# Altium schematic sheet DTRIG.SchDoc
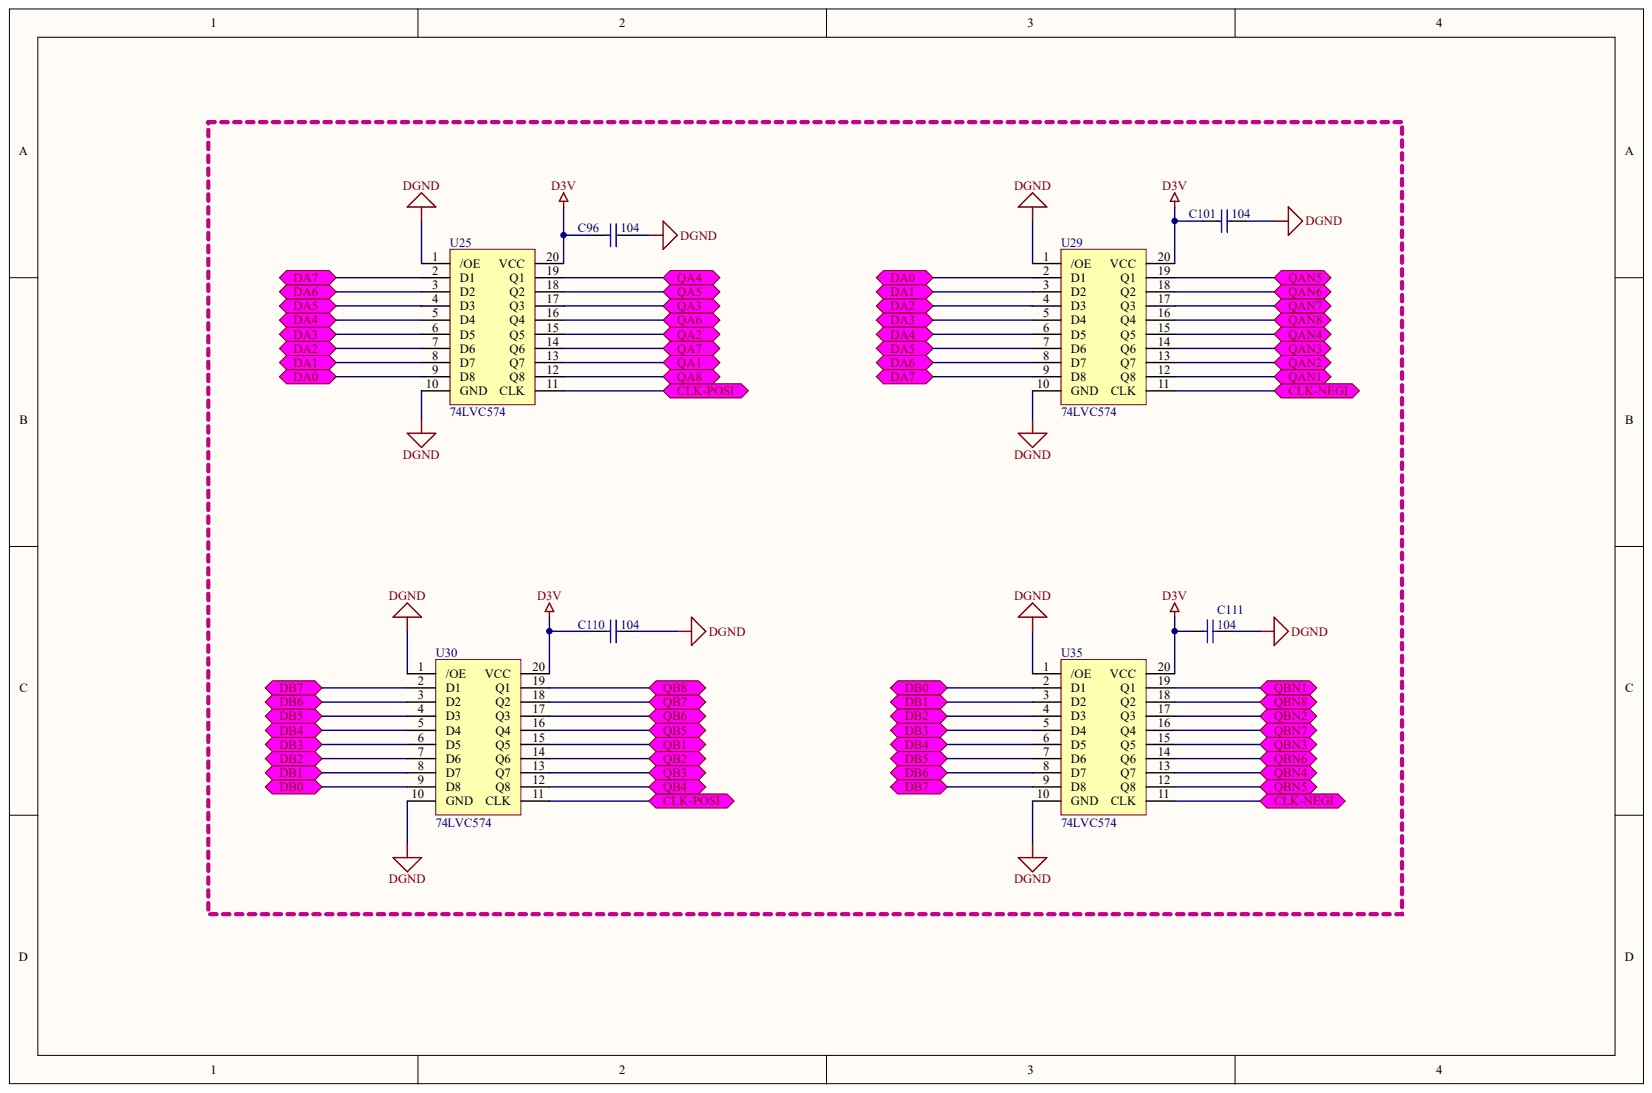
[diagram p0: DTRIG.SchDoc]

## Parts
C96  C104  (value not drawn)
C101  C104  (value not drawn)
C110  C104  (value not drawn)
C111  C104  (value not drawn)
U25  74LVC574
U29  74LVC574
U30  74LVC574
U35  74LVC574

## Ports
CLK-NEGI, CLK-POSI, DA0, DA1, DA2, DA3, DA4, DA5, DA6, DA7, DB0, DB1, DB2, DB3, DB4, DB5, DB6, DB7, QA1, QA2, QA3, QA4, QA5, QA6, QA7, QA8, QAN1, QAN2, QAN3, QAN4, QAN5, QAN6, QAN7, QAN8, QB1, QB2, QB3, QB4, QB5, QB6, QB7, QB8, QBN1, QBN2, QBN3, QBN4, QBN5, QBN6, QBN7, QBN8

## Nets
D3V: C96.1 C101.1 C110.1 C111.1 U25.20(VCC) U29.20(VCC) U30.20(VCC) U35.20(VCC)
DGND: C96.2 C101.2 C110.2 C111.2 U25.1(/OE) U25.10(GND) U29.1(/OE) U29.10(GND) U30.1(/OE) U30.10(GND) U35.1(/OE) U35.10(GND)
CLK-NEGI: U29.11(CLK) U35.11(CLK) ; port CLK-NEGI
CLK-POSI: U25.11(CLK) U30.11(CLK) ; port CLK-POSI
DA0: U25.9(D8) U29.2(D1) ; port DA0
DA1: U25.8(D7) U29.3(D2) ; port DA1
DA2: U25.7(D6) U29.4(D3) ; port DA2
DA3: U25.6(D5) U29.5(D4) ; port DA3
DA4: U25.5(D4) U29.6(D5) ; port DA4
DA5: U25.4(D3) U29.7(D6) ; port DA5
DA6: U25.3(D2) U29.8(D7) ; port DA6
DA7: U25.2(D1) U29.9(D8) ; port DA7
DB0: U30.9(D8) U35.2(D1) ; port DB0
DB1: U30.8(D7) U35.3(D2) ; port DB1
DB2: U30.7(D6) U35.4(D3) ; port DB2
DB3: U30.6(D5) U35.5(D4) ; port DB3
DB4: U30.5(D4) U35.6(D5) ; port DB4
DB5: U30.4(D3) U35.7(D6) ; port DB5
DB6: U30.3(D2) U35.8(D7) ; port DB6
DB7: U30.2(D1) U35.9(D8) ; port DB7
QA1: U25.13(Q7) ; port QA1
QA2: U25.15(Q5) ; port QA2
QA3: U25.17(Q3) ; port QA3
QA4: U25.19(Q1) ; port QA4
QA5: U25.18(Q2) ; port QA5
QA6: U25.16(Q4) ; port QA6
QA7: U25.14(Q6) ; port QA7
QA8: U25.12(Q8) ; port QA8
QAN1: U29.12(Q8) ; port QAN1
QAN2: U29.13(Q7) ; port QAN2
QAN3: U29.14(Q6) ; port QAN3
QAN4: U29.15(Q5) ; port QAN4
QAN5: U29.19(Q1) ; port QAN5
QAN6: U29.18(Q2) ; port QAN6
QAN7: U29.17(Q3) ; port QAN7
QAN8: U29.16(Q4) ; port QAN8
QB1: U30.15(Q5) ; port QB1
QB2: U30.14(Q6) ; port QB2
QB3: U30.13(Q7) ; port QB3
QB4: U30.12(Q8) ; port QB4
QB5: U30.16(Q4) ; port QB5
QB6: U30.17(Q3) ; port QB6
QB7: U30.18(Q2) ; port QB7
QB8: U30.19(Q1) ; port QB8
QBN1: U35.19(Q1) ; port QBN1
QBN2: U35.17(Q3) ; port QBN2
QBN3: U35.15(Q5) ; port QBN3
QBN4: U35.13(Q7) ; port QBN4
QBN5: U35.12(Q8) ; port QBN5
QBN6: U35.14(Q6) ; port QBN6
QBN7: U35.16(Q4) ; port QBN7
QBN8: U35.18(Q2) ; port QBN8

## Library data of the parts
C96 C101 C110 C111 U25 U29 U30 U35 = (no symbol name)
  C96 C101 C110 C111: : 104
